# Altium schematic sheet mics.SchDoc
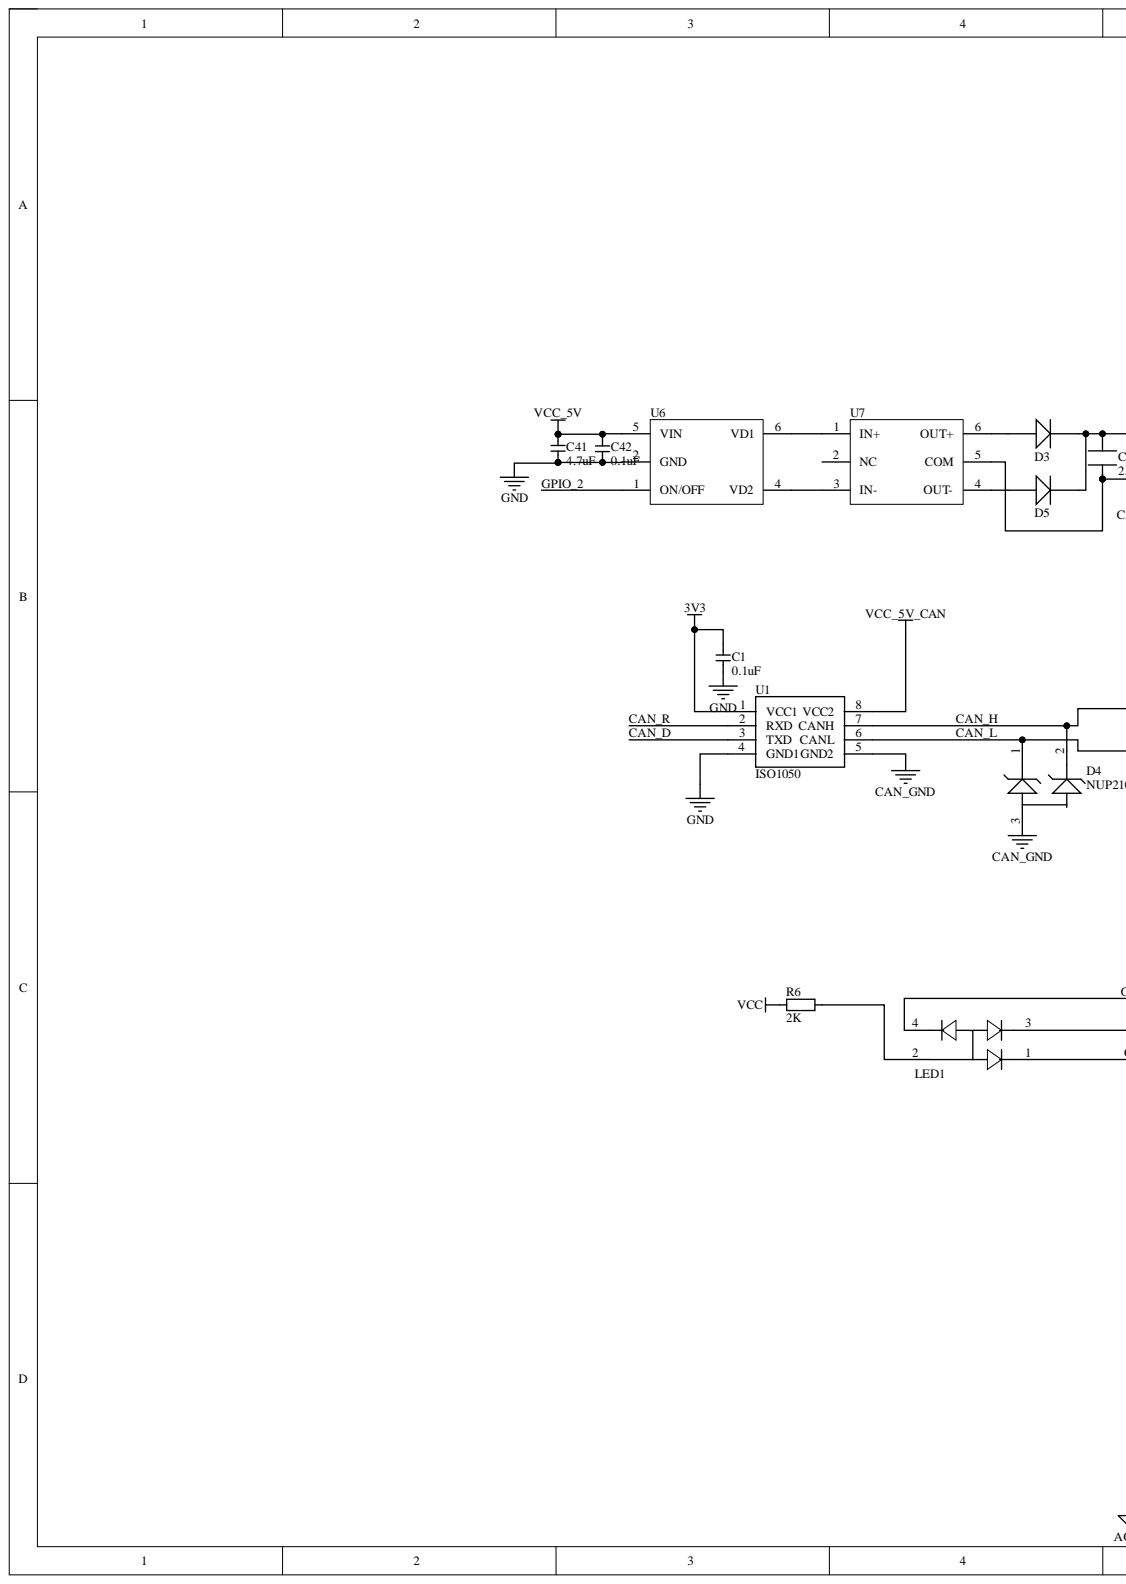
[diagram p0: mics.SchDoc, left]
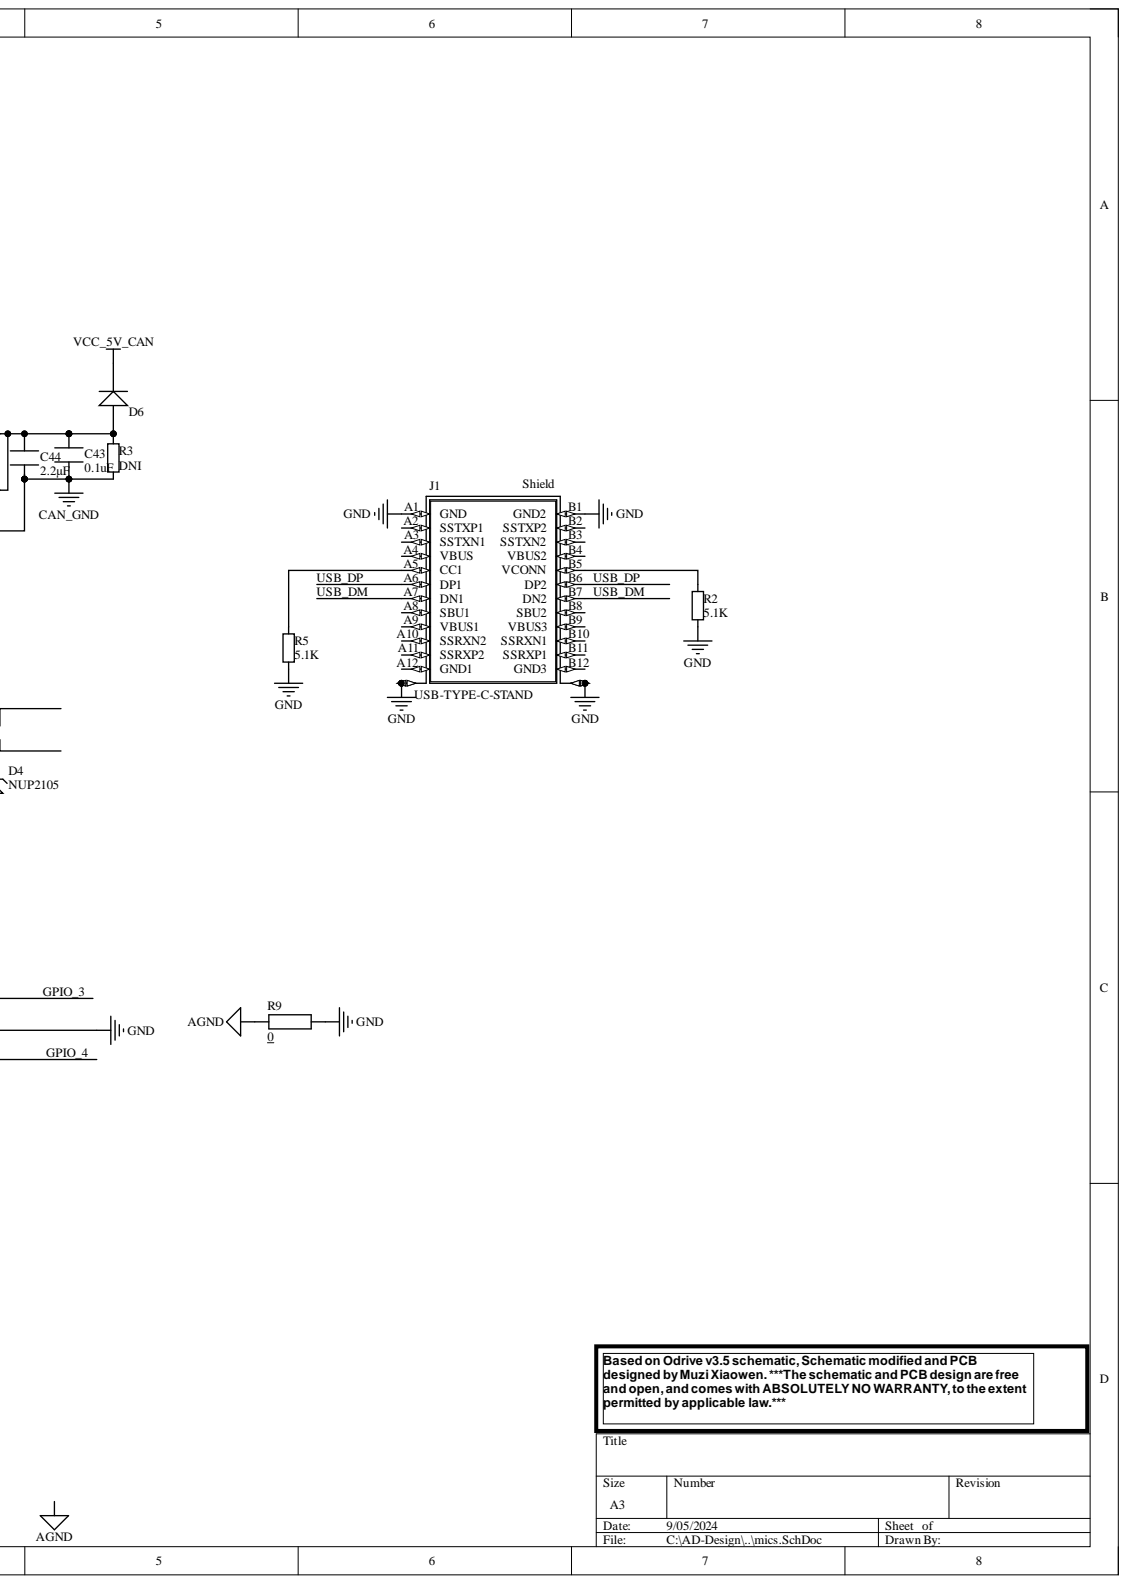
[diagram p1: mics.SchDoc, right]

## Parts
C1  0.1uF  [CAP_0402]
C41  4.7uF  [CAP_0402]
C42  0.1uF  [CAP_0402]
C43  0.1uF  [CAP_0402]
C44  2.2µF  [CAP_0402]
D3  二极管-5819W  [SOD-323]  (value not drawn)
D4  NUP2105  [SOT23-3]
D5  二极管-5819W  [SOD-323]  (value not drawn)
D6  二极管-5819W  [SOD-323]  (value not drawn)
J1  USB-TYPE-C-STAND  [USB-TYPE-C-STAND]
LED1  E6C0606RGBC3UDA  [RGB-0603-ok]  (value not drawn)
R2  5.1K  [RES_0402]
R3  DNI  [RES_0402]
R5  5.1K  [RES_0402]
R6  2K  [RES_0402]
R9  0  [RES_0402]
U1  ISO1050  [3d-SOIC-8_L9.3-W6.6-P2.54-LS10.4-BL]
U6  VPS8702  [SOT23-6]  (value not drawn)
U7  B0505  [NUP2105]  (value not drawn)

## Nets
3V3: C1.1 U1.1(VCC1)
AGND: R9.1
CAN_GND: C43.2 C44.1 D4.3 R3.1 U1.5(GND2) U7.5(COM)
GND: C1.2 C41.2 C42.1 J1.A1(GND) J1.B1(GND2) J1.S1 J1.S2 J1.S3 J1.S4 LED1.3 R2.2 R5.2 R9.2 U1.4(GND1) U6.2(GND)
VCC: R6.2
VCC_5V: C41.1 C42.2 U6.5(VIN)
VCC_5V_CAN: D6.2 U1.8(VCC2)
CAN_D_1: U1.3(TXD)  [also labelled CAN_D]
CAN_H_1: D4.2 U1.7(CANH)  [also labelled CAN_H]
CAN_L_1: D4.1 U1.6(CANL)  [also labelled CAN_L]
CAN_R_1: U1.2(RXD)  [also labelled CAN_R]
GPIO_2_1: U6.1(ON/OFF)  [also labelled GPIO_2]
GPIO_3_1: LED1.4  [also labelled GPIO_3]
GPIO_4_1: LED1.1  [also labelled GPIO_4]
USB_DM_1: J1.A7(DN1) J1.B7(DN2)  [also labelled USB_DM]
USB_DP_1: J1.A6(DP1) J1.B6(DP2)  [also labelled USB_DP]
NetC43_1: C43.1 C44.2 D3.2 D5.2 D6.1 R3.2
NetD3_1: D3.1 U7.6(OUT+)
NetD5_1: D5.1 U7.4(OUT-)
NetJ1_A5: J1.A5(CC1) R5.1
NetJ1_B5: J1.B5(VCONN) R2.1
NetLED1_2: LED1.2 R6.1
NetU6_4: U6.4(VD2) U7.3(IN-)
NetU6_6: U6.6(VD1) U7.1(IN+)

Not connected: J1 A2(SSTXP1) A3(SSTXN1) A4(VBUS) A8(SBU1) A9(VBUS1) A10(SSRXN2) A11(SSRXP2) A12(GND1) B2(SSTXP2) B3(SSTXN2) B4(VBUS2) B8(SBU2) B9(VBUS3) B10(SSRXN1) B11(SSRXP1) B12(GND3); U7 2(NC)

## Library data of the parts
C1 C41 C42 = 电容-0402: Capacitor; Value: 0.1u; Component Kind: Standard; Library Name: MyPcbLib.IntLib
C43 = GRM188R71H104KA93D: CAP CER 0.1UF 50V X7R 0603; Manufacturer: Murata Electronics North America; Tolerance: ±10%; Applications: General Purpose; Capacitance: 0.1uF; Category: Capacitors; Component Kind: Standard; ComponentLink1Description: http://www.murata.com/~/media/webrenewal/support/library/catalog/products/capacitor/mlcc/c02e.ashx?la=en-us; ComponentLink1URL: http://www.murata.com/~/media/webrenewal/support/library/catalog/products/capacitor/mlcc/c02e.ashx?la=en-us; Features: -; Height - Seated (Max): -; Lead Spacing: -; Lead Style: -; Library Name: CustomComponents.SchLib; Manufacturer Part Number: GRM188R71H104KA93D; Mounting Type: Surface Mount, MLCC; Operating Temperature: -55°C ~ 125°C; Package / Case: 0603 (1608 Metric); Packaging: Tape & Reel (TR); Part Status: Active; Pricing 1: 4000=0.01822, 8000=0.01663, 12000=0.01584 (USD); Pricing 2: 1=0.12, 10=0.084, 100=0.0396, 500=0.02778, 1000=0.023 (USD); Ratings: -; RoHS: RoHS Compliant; Size / Dimension: 0.063" L x 0.032" W (1.60mm x 0.80mm); Stock: 19812000; Supplier 1: Digi-Key; Supplier 2: Digi-Key; Supplier Part Number 1: 490-1519-2-ND; Supplier Part Number 2: 490-1519-1-ND; Temperature Coefficient: X7R; Thickness (Max): 0.035" (0.90mm); Voltage - Rated: 50V
C44 = GRM188R61C225KE15D: CAP CER 2.2UF 16V X5R 0603; Manufacturer: Murata Electronics North America; Tolerance: ±10%; Applications: General Purpose; Capacitance: 2.2µF; Category: Capacitors; Component Kind: Standard; ComponentLink1Description: http://www.murata.com/~/media/webrenewal/support/library/catalog/products/capacitor/mlcc/c02e.pdf; ComponentLink1URL: http://www.murata.com/~/media/webrenewal/support/library/catalog/products/capacitor/mlcc/c02e.pdf; Features: -; Height - Seated (Max): -; Lead Spacing: -; Lead Style: -; Library Name: CustomComponents.SchLib; Manufacturer Part Number: GRM188R61C225KE15D; Mounting Type: Surface Mount, MLCC; Operating Temperature: -55°C ~ 85°C; Package / Case: 0603 (1608 Metric); Packaging: Cut Tape (CT); Pricing 1: 1=0.12, 10=0.08, 50=0.046, 100=0.038, 250=0.032, 500=0.0268, 1000=0.022 (USD); Ratings: -; RoHS: RoHS Compliant; Size / Dimension: 0.063" L x 0.031" W (1.60mm x 0.80mm); Stock 1: 1255740; Supplier 1: Digi-Key; Supplier Part Number 1: 490-3296-1-ND; Temperature Coefficient: X5R; Thickness (Max): 0.035" (0.90mm); Voltage - Rated: 16V
D3 D5 D6 = 二极管-5819W: 40V; Component Kind: Standard; Library Name: MyPcbLib.IntLib
J1 = USB-TYPE-C-STAND: MF: Wurth Electronics; MP: 632722110112; Availability: Unavailable; Component Kind: Standard; DigiKey-Purchase-URL: https://snapeda.com/shop?store=DigiKey&id=3596593; Library Name: MyPcbLib.IntLib; Package: None; Price: None; SnapEDA_Link: https://www.snapeda.com/parts/632722110112/Wurth%20Electronics%20Inc./view-part/3596593/?ref=snap
R2 R5 R6 = 电阻-0402: Resistor; Value: 22R; Component Kind: Standard; Library Name: MyPcbLib.IntLib
R3 = 电阻-0402: Resistor; Value: 6.2K; Component Kind: Standard; Library Name: MyPcbLib.IntLib
R9 = RC0603FR-071KL: RES SMD 1K OHM 1% 1/10W 0603; Manufacturer: Yageo; Power (Watts): 0.1W, 1/10W; Temperature Coefficient: ±100ppm/°C; Category: Resistors; Component Kind: Standard; ComponentLink1Description: http://www.yageo.com/documents/recent/PYu-RC_Group_51_RoHS_L_04.pdf; ComponentLink1URL: http://www.yageo.com/documents/recent/PYu-RC_Group_51_RoHS_L_04.pdf; Composition: Thick Film; Features: Moisture Resistant; Height: 0.022" (0.55mm); Library Name: CustomComponents.SchLib; Manufacturer Part Number: RC0603FR-071KL; Number of Terminations: 2; Operating Temperature: -55°C ~ 155°C; Package / Case: 0603 (1608 Metric); Packaging: Cut Tape (CT); Pricing 1: 1=0.1, 10=0.014, 25=0.01, 100=0.0057, 250=0.00436, 500=0.00348, 1000=0.00257, 2500=0.00223 (USD); Resistance (Ohms): 1k; RoHS: RoHS Compliant; Size / Dimension: 0.063" L x 0.031" W (1.60mm x 0.80mm); Stock 1: 6734836; Supplier 1: Digi-Key; Supplier Device Package: 0603; Supplier Part Number 1: 311-1.00KHRCT-ND; Tolerance: ±1%
U1 = ISO1050: DIP Switches / SIP Switches 4 pos. low profile Btm Seal Tape J Bend; Manufacturer_Name: CTS; Manufacturer_Part_Number: 218-4LPSTJ; Geometry.Height: 2.7mm; Component Kind: Standard; Datasheet Link: https://www.ctscorp.com/wp-content/uploads/218.pdf; Library Name: Schlib1.SchLib; Mouser Part Number: 774-218-4LPSTJ; Mouser Price/Stock: https://www.mouser.co.uk/ProductDetail/CTS-Electronic-Components/218-4LPSTJ?qs=qNzHFtQhdJ%2Frpuf7cnHHWA%3D%3D
U6 = VPS8702: LDO; Component Kind: Standard; Library Name: Schlib1.SchLib
U7 = B0505: Transformer; Component Kind: Standard; Library Name: Schlib1.SchLib
D4 = NUP2105: Component Kind: Standard; Library Name: Schlib1.SchLib
LED1 = E6C0606RGBC3UDA: Component Kind: Standard; Library Name: OAKUSBFXS.SchLib
